# Altium schematic sheet [3]-MCU_MAIN.SchDoc([3]-MCU_MAIN)
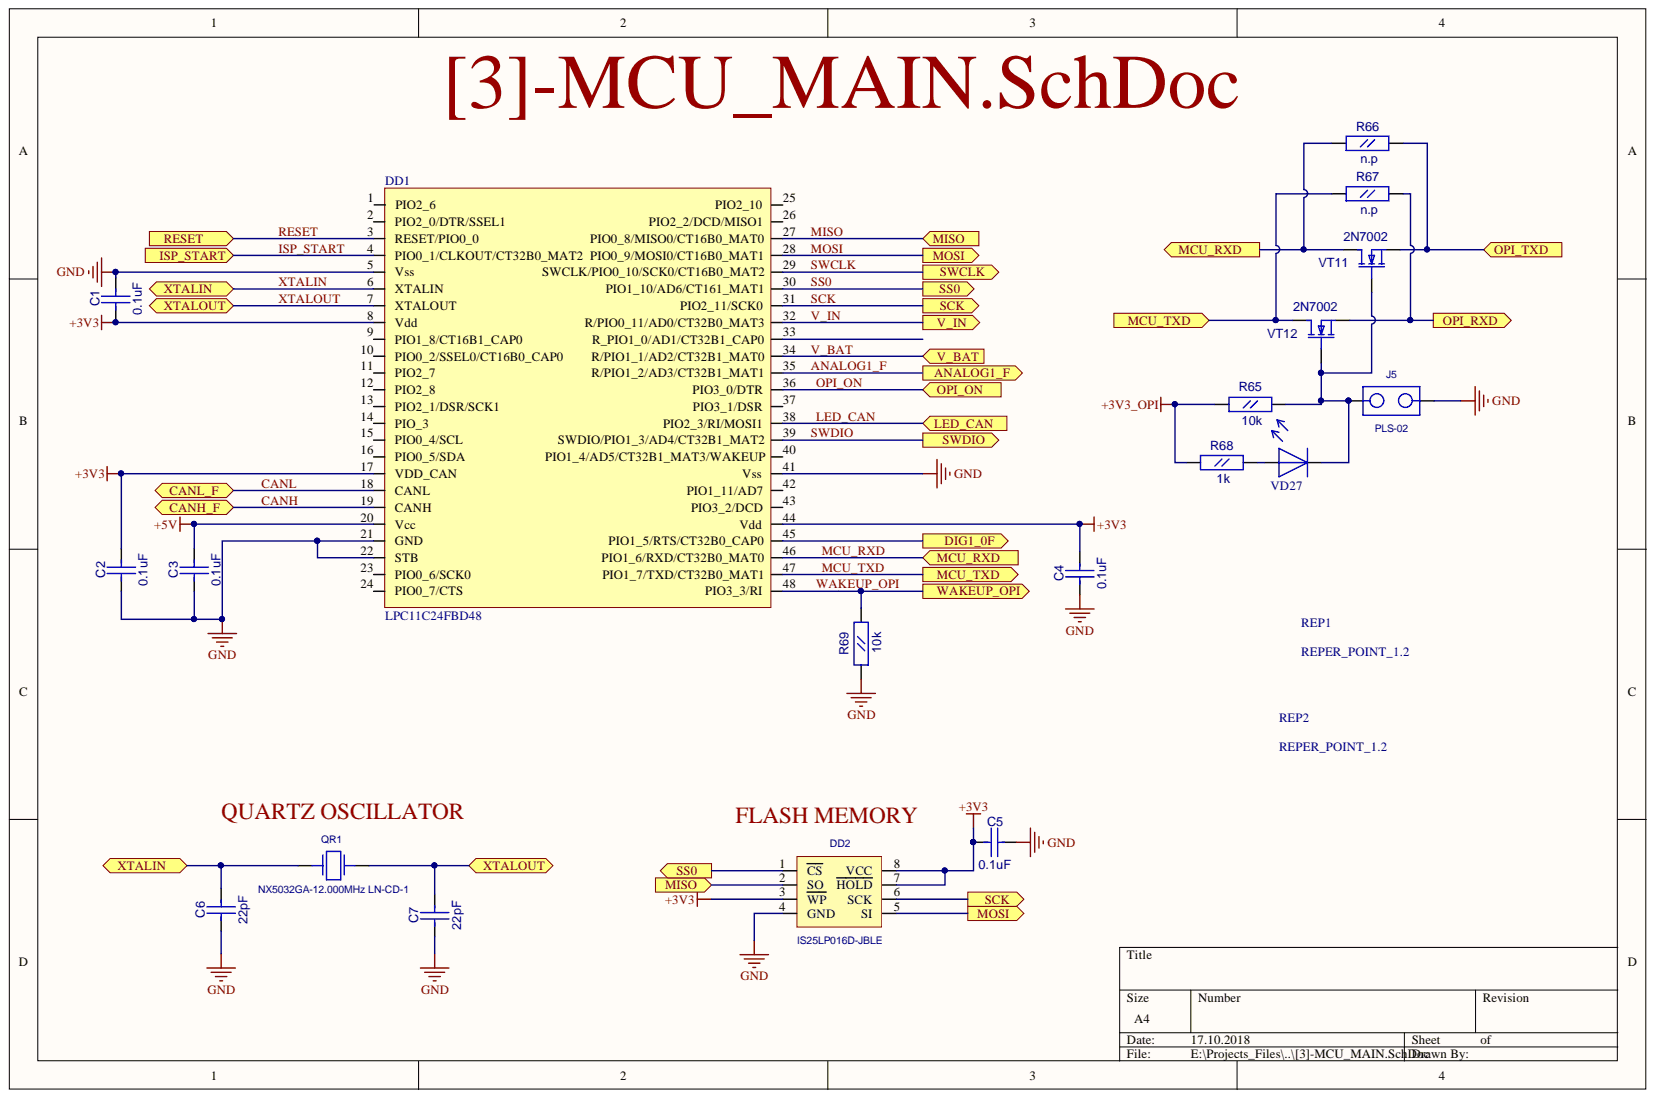
[diagram p0: [3]-MCU_MAIN.SchDoc]

## Parts
C1  0.1uF  [CC0603]
C2  0.1uF  [CC0603]
C3  0.1uF  [CC0603]
C4  0.1uF  [CC0603]
C5  0.1uF  [CC0603]
C6  22pF  [CC0603]
C7  22pF  [CC0603]
DD1  LPC11C24FBD48  [LQFP48]
DD2  IS25LP016D-JBLE  [EIAJ_SO8]
J5  PLS-02  [PLS-02]
QR1  NX5032GA-12.000MHz LN-CD-1  [AC5032_2]
R65  10k  [RC0603]
R66  n.p  [RC0603]
R67  n.p  [RC0603]
R68  1k  [RC0603]
R69  10k  [RC0603]
REP1  REPER_POINT_1.2  [REPER1.2]
REP2  REPER_POINT_1.2  [REPER1.2]
VD27  LED_0805  [LED0805]  (value not drawn)
VT11  2N7002  [SOT-23(123)]
VT12  2N7002  [SOT-23(123)]

## Ports
ANALOG1_F, CANH_F, CANL_F, DIG1_0F, ISP_START, LED_CAN, MCU_RXD, MCU_TXD, MISO, MOSI, OPI_ON, OPI_RXD, OPI_TXD, RESET, SCK, SS0, SWCLK, SWDIO, V_BAT, V_IN, WAKEUP_OPI, XTALIN, XTALOUT

## Nets
+3V3: C1.1 C2.2 C4.2 C5.1 DD1.8(Vdd) DD1.17(VDD_CAN) DD1.44(Vdd) DD2.3(WP) DD2.7(HOLD) DD2.8(VCC)
+3V3_OPI: R65.1 R68.1
+5V: C3.2 DD1.20(Vcc)
GND: C1.2 C2.1 C3.1 C4.1 C5.2 C6.1 C7.1 DD1.5(Vss) DD1.21(GND) DD1.22(STB) DD1.41(Vss) DD2.4(GND) J5.2 R69.1
ANALOG1_F: DD1.35(R/PIO1_2/AD3/CT32B1_MAT1) ; port ANALOG1_F
CANH: DD1.19(CANH) ; port CANH_F
CANL: DD1.18(CANL) ; port CANL_F
DIG1_0F: DD1.45(PIO1_5/RTS/CT32B0_CAP0) ; port DIG1_0F
ISP_START: DD1.4 ; port ISP_START
LED_CAN: DD1.38(PIO2_3/RI/MOSI1) ; port LED_CAN
MCU_RXD: DD1.46(PIO1_6/RXD/CT32B0_MAT0) R66.1 VT11.3 ; port MCU_RXD
MCU_TXD: DD1.47(PIO1_7/TXD/CT32B0_MAT1) R67.1 VT12.3 ; port MCU_TXD
MISO: DD1.27 DD2.2(SO) ; port MISO
MOSI: DD1.28 DD2.5(SI) ; port MOSI
OPI_ON: DD1.36(PIO3_0/DTR) ; port OPI_ON
OPI_RXD: R67.2 VT12.2 ; port OPI_RXD
OPI_TXD: R66.2 VT11.2 ; port OPI_TXD
RESET: DD1.3(RESET/PIO0_0) ; port RESET
SCK: DD1.31(PIO2_11/SCK0) DD2.6(SCK) ; port SCK
SS0: DD1.30(PIO1_10/AD6/CT161_MAT1) DD2.1(CS) ; port SS0
SWCLK: DD1.29(SWCLK/PIO0_10/SCK0/CT16B0_MAT2) ; port SWCLK
SWDIO: DD1.39(SWDIO/PIO1_3/AD4/CT32B1_MAT2) ; port SWDIO
V_BAT: DD1.34(R/PIO1_1/AD2/CT32B1_MAT0) ; port V_BAT
V_IN: DD1.32(R/PIO0_11/AD0/CT32B0_MAT3) ; port V_IN
WAKEUP_OPI: DD1.48(PIO3_3/RI) R69.2 ; port WAKEUP_OPI
XTALIN: C6.2 DD1.6(XTALIN) QR1.1 ; port XTALIN
XTALOUT: C7.2 DD1.7(XTALOUT) QR1.2 ; port XTALOUT
NetJ5_1: J5.1 R65.2 VD27.2 VT11.1 VT12.1
NetR68_2: R68.2 VD27.1

Not connected: DD1 1(PIO2_6) 2(PIO2_0/DTR/SSEL1) 9(PIO1_8/CT16B1_CAP0) 10 11(PIO2_7) 12(PIO2_8) 13(PIO2_1/DSR/SCK1) 14(PIO_3) 15 16 23 24 25(PIO2_10) 26(PIO2_2/DCD/MISO1) 33(R_PIO1_0/AD1/CT32B1_CAP0) 37(PIO3_1/DSR) 40(PIO1_4/AD5/CT32B1_MAT3/WAKEUP) 42(PIO1_11/AD7) 43(PIO3_2/DCD)

## Library data of the parts
C1-C7 = CC0603: Description: CC0603; Component Kind: Standard
  C1-C5: Value: 0.1uF
  C6 C7: Value: 22pF
  C1-C4: Library Name: fizik_lib.SchLib
  C5-C7: Library Name: gprs_modem_2.SchLib
DD2 = S25FL016A0LMFI01: Value: IS25LP016D-JBLE; Description: S25FL016A0LMFI01; Component Kind: Standard; Library Name: gprs_modem_2.SchLib
QR1 = AC5032_2: AC5032/2; Value: NX5032GA-12.000MHz LN-CD-1; Component Kind: Standard; Library Name: gprs_modem_2.SchLib
R65-R69 = RC0603: Description: RC0603; Component Kind: Standard; Library Name: fizik_lib.SchLib
  R65 R69: Value: 10k
  R66 R67: Value: n.p
  R68: Value: 1k
REP1 REP2 = REPER1.2: Value: REPER_POINT_1.2; Description: REPER1.2; Component Kind: Standard; Library Name: fizik_lib.SchLib
VT11 VT12 = 2N7002: N-Channel Enhancement Mode Vertical DMOS FET; Simulation: 2N7002; OriginalName: 2N7002; Component Kind: Standard; Library Name: fizik_lib.SchLib
DD1 = LPC11C24FBD48: Value: LPC11C24FBD48; Component Kind: Standard; Library Name: fizik_lib.SchLib
J5 = PLS-02: Description: PLS-02; Component Kind: Standard; Library Name: fizik_lib.SchLib
VD27 = LED_0805: Value: LED_0805; Component Kind: Standard; Library Name: fizik_lib.SchLib
